# Altium schematic sheet S1_Connections.SchDoc(S1_Connections)
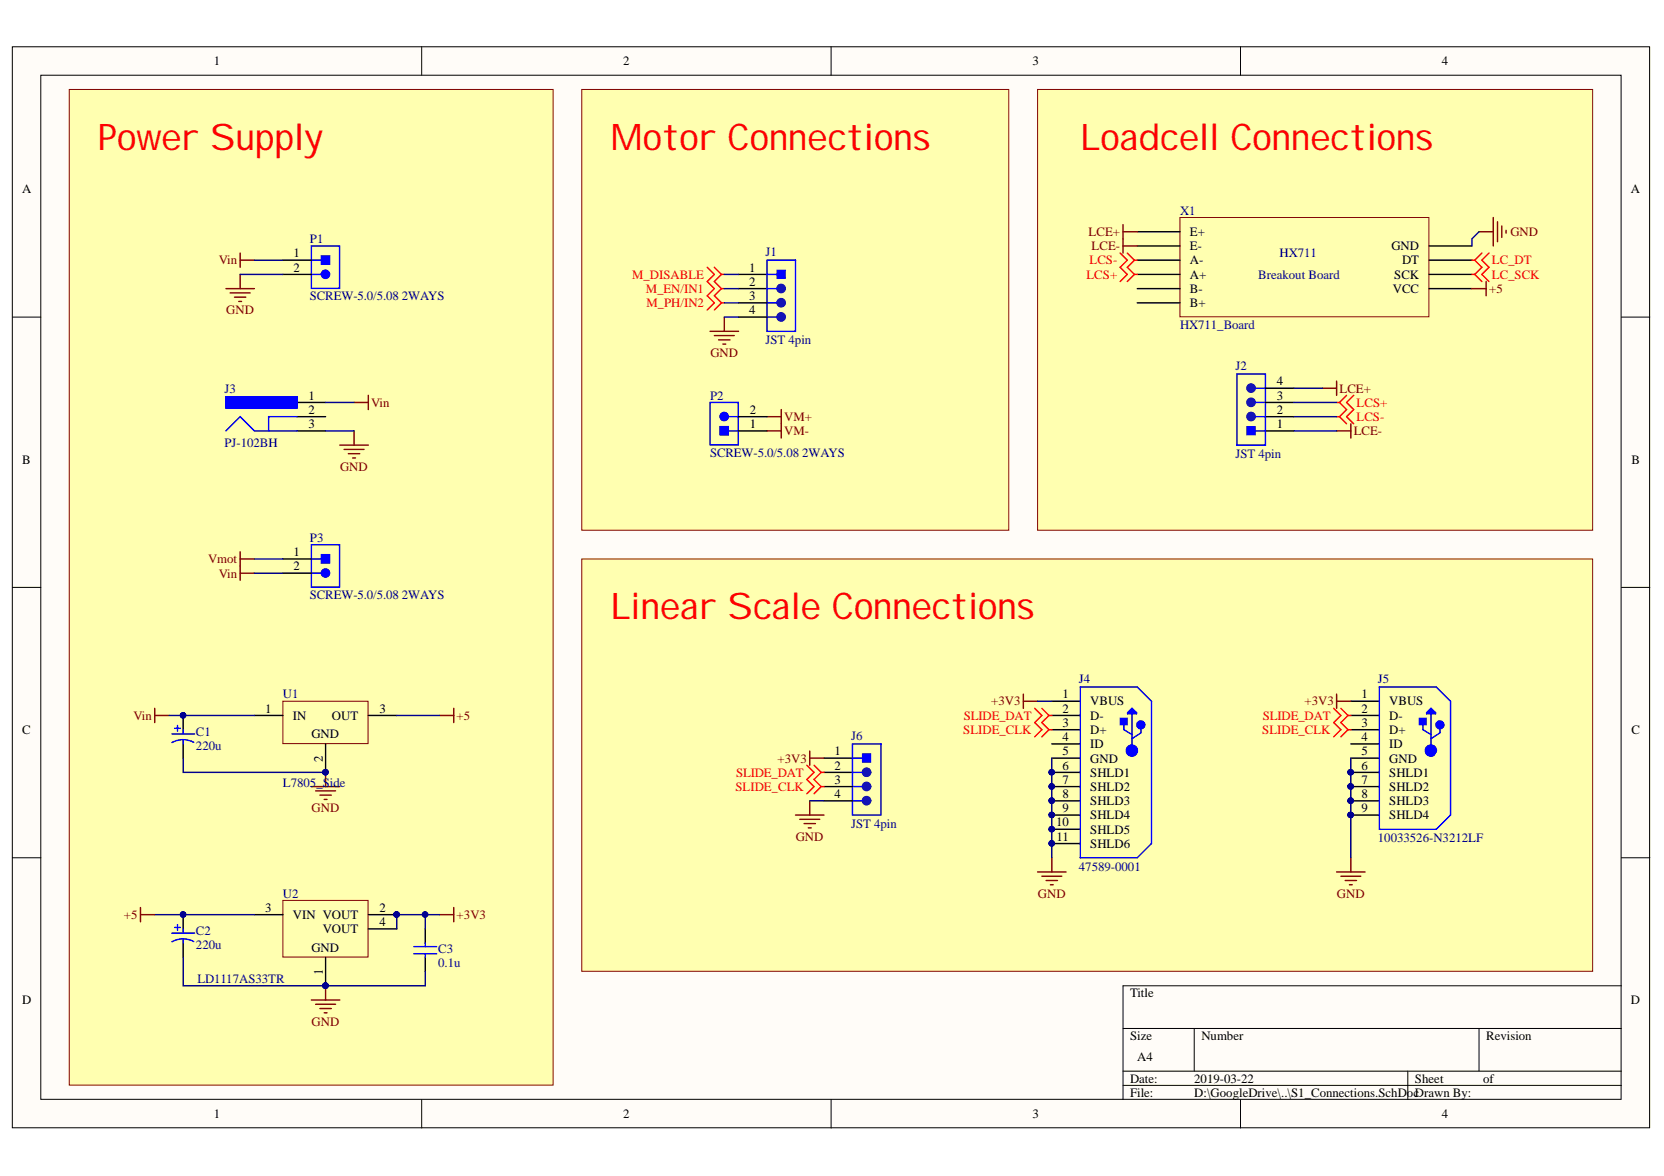
[diagram p0: S1_Connections.SchDoc]

## Parts
C1  220u  [Alu Cap 6.3x2.5mm]
C2  220u  [Alu Cap 6.3x2.5mm]
C3  0.1u  [Ceramic Cap 5mm]
J1  JST 4pin  [4Pin JST]
J2  JST 4pin  [4Pin JST]
J3  PJ-102BH  [CUI-PJ-102BH_V]
J4  47589-0001  [MOLX-47589-0001_V]
J5  10033526-N3212LF  [FCI-10033526-N3212LF_V]
J6  JST 4pin  [4Pin JST]
P1  SCREW-5.0/5.08 2WAYS  [SCREW-5.0-2WAYS_V]
P2  SCREW-5.0/5.08 2WAYS  [SCREW-5.0-2WAYS_V]
P3  SCREW-5.0/5.08 2WAYS  [SCREW-5.0-2WAYS_V]
U1  L7805_Side  [TO220_Side Mount]
U2  LD1117AS33TR  [SOT-223_M]
X1  HX711_Board  [HX711_Board_Large]

## Nets
+3V3: C3.1 J4.1(VBUS) J5.1(VBUS) J6.1 U2.2(VOUT) U2.4(VOUT)
+5: C2.1 U1.3 U2.3(VIN) X1.7(VCC)
GND: C1.2 C2.2 C3.2 J1.4 J3.3 J4.5(GND) J4.6(SHLD1) J4.7(SHLD2) J4.8(SHLD3) J4.9(SHLD4) J4.10(SHLD5) J4.11(SHLD6) J5.5(GND) J5.6(SHLD1) J5.7(SHLD2) J5.8(SHLD3) J5.9(SHLD4) J6.4 P1.2 U1.2 U2.1 X1.10(GND)
LC_DT: X1.9(DT)
LC_SCK: X1.8(SCK)
LCE+: J2.4 X1.1(E+)
LCE-: J2.1 X1.2(E-)
LCS+: J2.3 X1.4(A+)
LCS-: J2.2 X1.3(A-)
M_DISABLE: J1.1
M_EN/IN1: J1.2
M_PH/IN2: J1.3
SLIDE_CLK: J4.3(D+) J5.3(D+) J6.3
SLIDE_DAT: J4.2(D-) J5.2(D-) J6.2
Vin: C1.1 J3.1 P1.1 P3.2 U1.1
VM+: P2.2
VM-: P2.1
Vmot: P3.1

Not connected: J3 2; J4 4(ID); J5 4(ID); X1 5(B-) 6(B+)

## Library data of the parts
C1 C2 = Polar Cap: Library Name: Res and Cap.SchLib; Component Kind: Standard
C3 = Cap: Library Name: Res and Cap.SchLib; Component Kind: Standard
J1 J2 J6 = 4Pin JST: Library Name: Custom.SchLib; Component Kind: Standard
J3 = CMP-2000-06901-1: Through Hole Right Angle DC Power Jack, 5 A, 2.5 mm Center Pin, 3 Position, -25 to 85 degC, RoHS, Bulk; Manufacturer: CUIInc.; Voltage Rating: 24V; Outside Contact Diameter: 6.5mm; Insulation Resistance: 100MOhms; Inside Contact Diameter: 2.5mm; Current Rating: 5A; Brand: CUI; Termination Style: ThroughHole; RoHS: True; Product Category: DCPowerConnectors; Product: Jacks; Package Reference: PJ-102BH; Package Description: 3-Pin Through Hole Device, Body 14.4 x 9 mm, Height 11 mm; Operating Temperature Range: -25Cto+85C; Mounting Technology: ThroughHole; Mounting Style: ThroughHole; Mounting Angle: Right; Manufacturer URL: http://www.cui.com/; Library Name: Altium Content Vault; Housing Material: PolybutyleneTerephthalate(PBT); Flammability Rating: UL94V-0; Factory Pack Quantity: 280; Datasheet Version: Rev. 1.02, 05/2016; Datasheet URL: http://www.cui.com/product/resource/pj-102bh.pdf; Contact Plating: Nickel; Contact Material: Copper; Contact Gender: Male; Connector Type: DCPowerJack; Component Kind: Standard
J4 = CMP-2000-05610-1: Right Angle Female Type AB Micro USB Connector, 30 V, 1.8 A, -30 to 85 degC, RoHS, Tape and Reel; Manufacturer: Molex; Voltage Rating: 30VAC; Number of Ports: 1Port; Number of Contacts: 5Contact; Current Rating: 1.8A; Connector Type: MicroUSBTypeABreceptacle; Termination Style: SMD/SMT; Standard: USB; Series: 47589; RoHS: True; Product Category: USBConnectors; Product: MicroUSBTypeABConnectors; Packaging: Reel; Package URL: http://www.molex.com/pdm_docs/sd/475890001_sd.pdf; Package Reference: 47589-0001; Package Description: Micro USB Connector, Pitch 0.65 mm, 5 Positions, Body 7.5 x 5.6 mm; Operating Temperature Range: -20Cto+85C; Mounting Technology: SurfaceMount; Mounting Angle: Right; Manufacturer URL: http://www.molex.com/; Library Name: Altium Content Vault; Gender: Female; Datasheet Version: 12/2016; Datasheet URL: http://www.molex.com/webdocs/datasheets/pdf/en-us/0475890001_IO_CONNECTORS.pdf; Contact Plating: Gold; Contact Material: CopperAlloy; Component Kind: Standard; Color: Black; Brand: Molex; : 0475890001
J5 = CMP-1698-00001-2: Mini USB B-Type, 5-Pin SMD, RoHS, Tape and Reel; Manufacturer: FCI; Number of Ports: 1 Port; Number of Contacts: 5 Contact; Mounting Angle: Right; Insulation Resistance: 100 MOhms; Gender: Female; Connector Type: Mini USB Type B Receptacle; Termination Style: Solder Pad; Standard: USB; Shell Plating: Nickel; RoHS: Details; Product Category: USB Connectors; Product: Mini USB Type B Connectors; PartNumber: 10033526-N3212LF; Packaging: Reel; PackageDescription: 5-Pin Surface Mount Device, Body 9.2 x 7.7 mm, Pitch 0.8 mm; Mounting Technology: Surface Mount; Library Name: Altium Content Vault; Flammability Rating: UL 94 V-0; Factory Pack Quantity: 750; DatasheetVersion: Rev. N; Contact Plating: Gold; Contact Material: Copper Alloy; ComponentLink2URL: http://portal.fciconnect.com/Comergent//fci/drawing/10033526.pdf; ComponentLink2Description: Datasheet; ComponentLink1URL: http://portal.fciconnect.com/; ComponentLink1Description: Manufacturer URL; Component Kind: Standard; Color: Black; Brand: FCI
P1-P3 = CMP-0617-00009-1: Socket, Screw Terminal Block, 2 Way, 5.0 mm Pitch, 90 Degree Angle, Through-Hole; Manufacturer: No Vendor; Rated Voltage: 300 V; Rated Current: 25 A; Poles: 2; Insulation Resistance: 5000 MOhm / 1000 V; Contact Resistance: 20 mOhm; Temperature Range: -40 to 105 degC; PartNumber: SCREW-5.0/5.08 2WAYS; PackageDescription: Socket, Screw Terminal Block, 2 Way, Pitch 5 mm, 90 Degree Angle, Through-Hole; Mounting Technology: Through Hole; Library Name: Altium Content Vault; ComponentLink1URL: 5mm screw terminal in SVN; ComponentLink1Description: Datasheet; Component Kind: Standard
U2 = CMP-0244-00316-1: 1A, Low Drop Positive Voltage Regulator, 3.3V, 4-Pin SOT-223, Tape and Reel; PackageVersion: 2010; PackageReference: SOT-223; PackageDescription: 4-Pin SOT-223 Package, 6.5 x 3.5 mm Body, 2.3 mm Pitch, 7 mm Lead Span; Library Name: Altium Content Vault; DatasheetVersion: 2/12/2011; ComponentLink2URL: http://www.st.com/internet/com/TECHNICAL_RESOURCES/TECHNICAL_LITERATURE/DATASHEET/CD00002116.pdf; ComponentLink2Description: Datasheet; ComponentLink1URL: http://www.st.com; ComponentLink1Description: Manufacturer URL; Component Kind: Standard
U1 = L7805_Side: Library Name: Custom.SchLib; Component Kind: Standard
X1 = HX711_Board: Library Name: Custom.SchLib; Component Kind: Standard
